# Altium schematic sheet Power.SchDoc(Power)
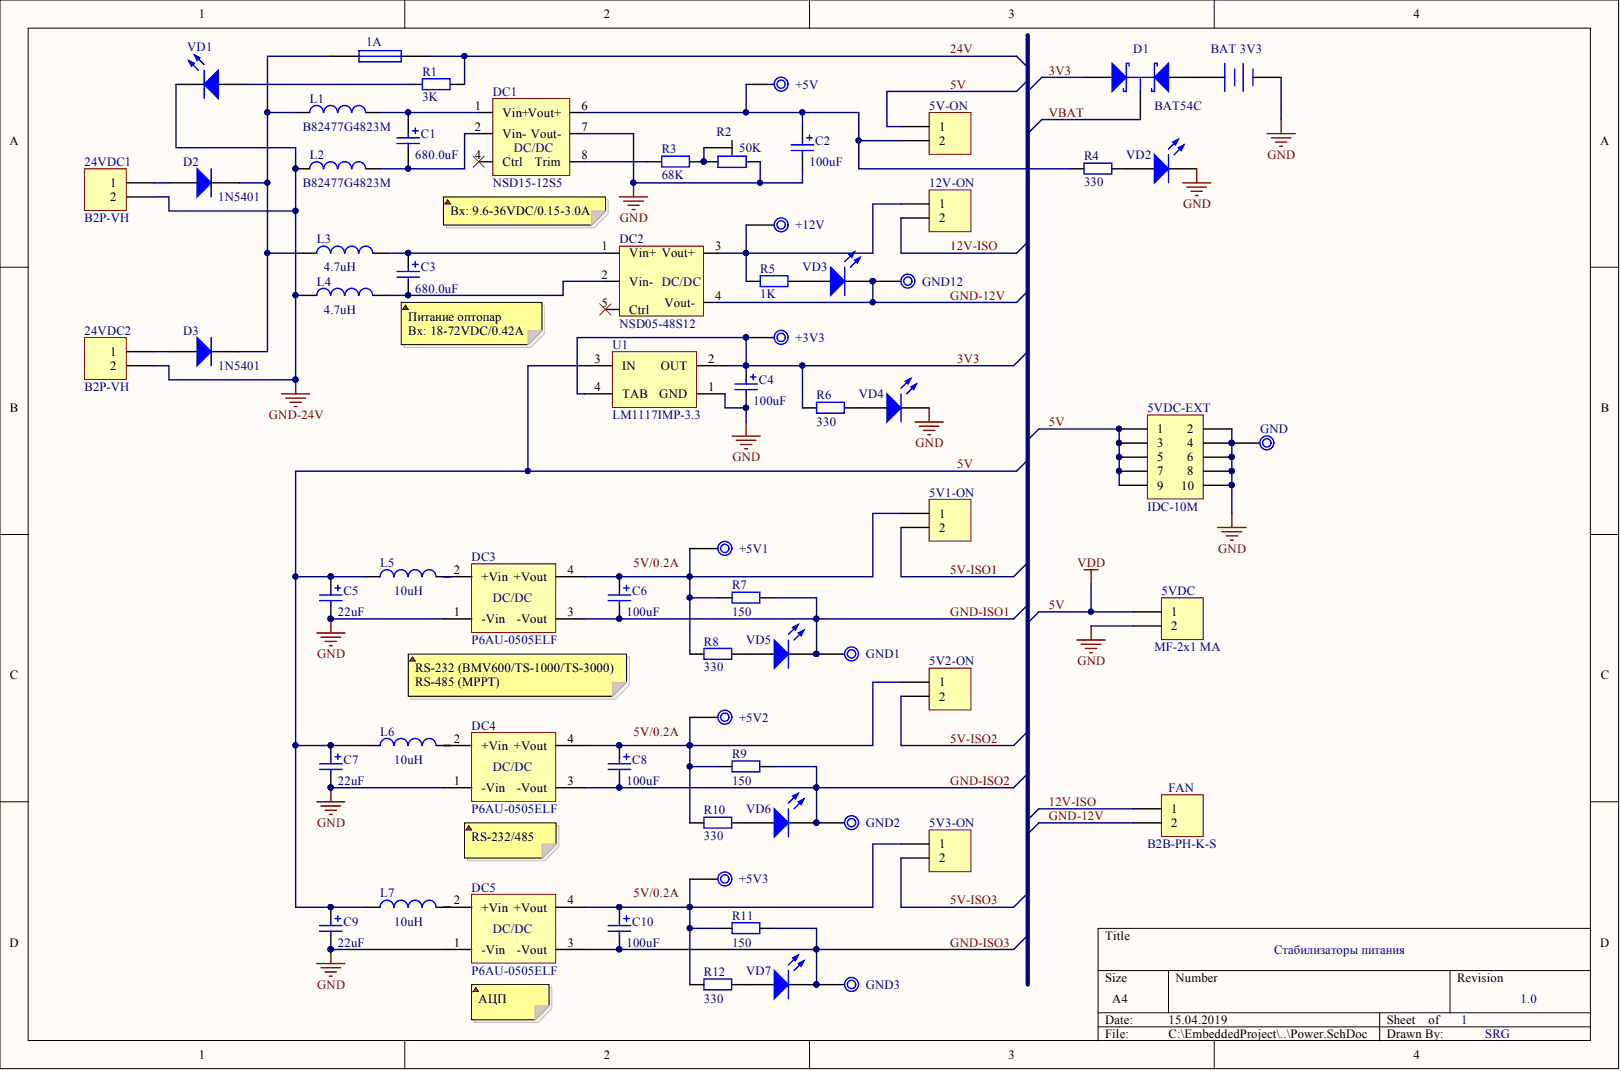
[diagram p0: Power.SchDoc]

## Parts
1A  value 1A  [FUSE RAD]  (hidden comment: Fuse 1)
5V1-ON  JP+12  [HDR1X2]  (value not drawn)
5V2-ON  JP+12  [HDR1X2]  (value not drawn)
5V3-ON  JP+12  [HDR1X2]  (value not drawn)
5V-ON  JP2  [HDR1X2]  (value not drawn)
5VDC  value MF-2x1 MA  [MF-2x1 MR]  (hidden comment: Header, 2-Pin)
5VDC-EXT  value IDC-10M  [IDC10]  (hidden comment: Header, 10-Pin)
12V-ON  JP2  [HDR1X2]  (value not drawn)
24VDC1  value B2P-VH  [B2P-VH]  (hidden comment: Header, 2-Pin)
24VDC2  value B2P-VH  [B2P-VH]  (hidden comment: Header, 2-Pin)
+3V3  Plug  [PIN1]  (value not drawn)
+5V  Plug  [PIN1]  (value not drawn)
+5V1  Plug  [PIN1]  (value not drawn)
+5V2  Plug  [PIN1]  (value not drawn)
+5V3  Plug  [PIN1]  (value not drawn)
+12V  Plug  [PIN1]  (value not drawn)
BAT_3V3  BAT 3V3  [CH-74-2032]
C1  value 680.0uF  [El-d13-h21-F5.08]  (hidden comment: Polarized Capacitor)
C2  value 100uF  [D]  (hidden comment: Polarized Capacitor)
C3  value 680.0uF  [El-d13-h21-F5.08]  (hidden comment: Polarized Capacitor)
C4  value 100uF  [D]  (hidden comment: Polarized Capacitor)
C5  value 22uF  [D]  (hidden comment: Polarized Capacitor)
C6  value 100uF  [D]  (hidden comment: Polarized Capacitor)
C7  value 22uF  [D]  (hidden comment: Polarized Capacitor)
C8  value 100uF  [D]  (hidden comment: Polarized Capacitor)
C9  value 22uF  [D]  (hidden comment: Polarized Capacitor)
C10  value 100uF  [D]  (hidden comment: Polarized Capacitor)
D1  value BAT54C  [SOT23_BAT54]  (hidden comment: Schottky Barrier Double Diode)
D2  value 1N5401  [DO-41]  (hidden comment: Diode 1N5401)
D3  value 1N5401  [DO-41]  (hidden comment: Diode 1N5401)
DC1  NSD15-12S5  [NSD15-12S5]
DC2  NSD05-48S12  [NSD05-12S12]
DC3  P6AU-0505ELF  [SIP4]
DC4  P6AU-0505ELF  [SIP4]
DC5  P6AU-0505ELF  [SIP4]
FAN  value B2B-PH-K-S  [B2B-PH-K-S]  (hidden comment: Header, 2-Pin)
GND  Plug  [PIN1]  (value not drawn)
GND1  Plug  [PIN1]  (value not drawn)
GND2  Plug  [PIN1]  (value not drawn)
GND3  Plug  [PIN1]  (value not drawn)
GND12  Plug  [PIN1]  (value not drawn)
L1  value B82477G4823M  [B82477G4823M]  (hidden comment: Inductor)
L2  value B82477G4823M  [B82477G4823M]  (hidden comment: Inductor)
L3  value 4.7uH  [Axial 12]  (hidden comment: Inductor)
L4  value 4.7uH  [Axial 12]  (hidden comment: Inductor)
L5  value 10uH  [Axial 12]  (hidden comment: Inductor)
L6  value 10uH  [Axial 12]  (hidden comment: Inductor)
L7  value 10uH  [Axial 12]  (hidden comment: Inductor)
R1  value 3K  [1206]  (hidden comment: Res2)
R2  value 50K  [RES-3296W]  (hidden comment: Resistor TAP)
R3  value 68K  [1206]  (hidden comment: Res2)
R4  value 330  [1206]  (hidden comment: Res2)
R5  value 1K  [1206]  (hidden comment: Res2)
R6  value 330  [1206]  (hidden comment: Res2)
R7  value 150  [MLT-0.5W-500]  (hidden comment: Res2)
R8  value 330  [1206]  (hidden comment: Res2)
R9  value 150  [RES 12.7x3.0]  (hidden comment: Res2)
R10  value 330  [1206]  (hidden comment: Res2)
R11  value 150  [RES 12.7x3.0]  (hidden comment: Res2)
R12  value 330  [1206]  (hidden comment: Res2)
U1  LM1117IMP-3.3  [MP04A_N]
VD1  +24V-OK  [LED1206 - GREEN]  (value not drawn)
VD2  5V  [LED1206 - GREEN]  (value not drawn)
VD3  12V  [LED1206 - BLUE]  (value not drawn)
VD4  3V3  [LED1206 - GREEN]
VD5  5V1  [LED1206 - BLUE]  (value not drawn)
VD6  5V2  [LED1206 - BLUE]  (value not drawn)
VD7  5V3  [LED1206 - BLUE]  (value not drawn)

## Nets
GND: 5VDC.2 5VDC-EXT.2 5VDC-EXT.4 5VDC-EXT.6 5VDC-EXT.8 5VDC-EXT.10 BAT_3V3.2 C2.2 C4.2 C5.2 C7.2 C9.2 DC1.7(Vout-) DC3.1(-Vin) DC4.1(-Vin) DC5.1(-Vin) GND.1 R2.1 U1.1(GND) VD2.2 VD4.2
GND-24V: 24VDC1.2 24VDC2.2 L2.1 L4.1 VD1.2
3V3: +3V3.1 C4.1 D1.1 R6.2 U1.2(OUT) U1.4(TAB)
5V: 5V-ON.1 5VDC.1 5VDC-EXT.1 5VDC-EXT.3 5VDC-EXT.5 5VDC-EXT.7 5VDC-EXT.9 C5.1 C7.1 C9.1 L5.1 L6.1 L7.1 U1.3(IN)
5V-ISO1: 5V1-ON.2
5V-ISO2: 5V2-ON.2
5V-ISO3: 5V3-ON.2
12V-ISO: 12V-ON.2 FAN.1
24V: 1A.2 R1.2
GND-12V: DC2.4(Vout-) FAN.2 GND12.1 VD3.2
GND-ISO1: C6.2 DC3.3(-Vout) GND1.1 R7.1 VD5.2
GND-ISO2: C8.2 DC4.3(-Vout) GND2.1 R9.1 VD6.2
GND-ISO3: C10.2 DC5.3(-Vout) GND3.1 R11.1 VD7.2
VBAT: D1.3
Net1A_1: 1A.1 D2.2 D3.2 L1.1 L3.1
Net24VDC1_1: 24VDC1.1 D2.1
Net24VDC2_1: 24VDC2.1 D3.1
Net+5V1_1: 5V1-ON.1 +5V1.1 C6.1 DC3.4(+Vout) R7.2 R8.2
Net+5V2_1: 5V2-ON.1 +5V2.1 C8.1 DC4.4(+Vout) R9.2 R10.2
Net+5V3_1: 5V3-ON.1 +5V3.1 C10.1 DC5.4(+Vout) R11.2 R12.2
Net+5V_1: 5V-ON.2 +5V.1 C2.1 DC1.6(Vout+) R4.2
Net+12V_1: 12V-ON.1 +12V.1 DC2.3(Vout+) R5.2
NetBAT 3V3_1: BAT_3V3.1 D1.2
NetC1_1: C1.1 DC1.1(Vin+) L1.2
NetC1_2: C1.2 DC1.2(Vin-) L2.2
NetC3_1: C3.1 DC2.1(Vin+) L3.2
NetC3_2: C3.2 DC2.2(Vin-) L4.2
NetDC1_8: DC1.8(Trim) R3.2
NetDC3_2: DC3.2(+Vin) L5.2
NetDC4_2: DC4.2(+Vin) L6.2
NetDC5_2: DC5.2(+Vin) L7.2
NetR1_1: R1.1 VD1.1
NetR2_2: R2.2 R2.3 R3.1
NetR4_1: R4.1 VD2.1
NetR5_1: R5.1 VD3.1
NetR6_1: R6.1 VD4.1
NetR8_1: R8.1 VD5.1
NetR10_1: R10.1 VD6.1
NetR12_1: R12.1 VD7.1
N00552:

Not connected: DC1 4(Ctrl); DC2 5(Ctrl)

## Library data of the parts
1A = Fuse 1: Fuse; Component Kind: Standard; LatestRevisionDate: 17-Jul-2002; LatestRevisionNote: Re-released for DXP Platform.; Library Name: Miscellaneous Devices.IntLib; PackageDescription: Thru-Hole; 2 Leads; PackageReference: PIN-W2/E2.8; Published: 8-Jun-2000; Simulation: FUSE; Publisher: Altium Limited
5V1-ON 5V2-ON 5V3-ON 5V-ON 12V-ON = Header 2: Header, 2-Pin; value: Jumper; Component Kind: Standard; LatestRevisionDate: 17-Jul-2002; LatestRevisionNote: Re-released for DXP Platform.; Library Name: Miscellaneous Connectors.IntLib; Signal Integrity: Connector; Publisher: Altium Limited
5VDC 24VDC1 24VDC2 FAN = Header 2: Component Kind: Standard; Description: Header, 2-Pin; LatestRevisionDate: 17-Jul-2002; LatestRevisionNote: Re-released for DXP Platform.; Library Name: Miscellaneous Connectors.IntLib; Signal Integrity: Connector; Publisher: Altium Limited
5VDC-EXT = Header 5X2: Component Kind: Standard; Description: Header, 10-Pin; LatestRevisionDate: 17-Jul-2002; LatestRevisionNote: Re-released for DXP Platform.; Library Name: Miscellaneous Connectors.IntLib; Signal Integrity: Connector; Publisher: Altium Limited
BAT_3V3 = Battery: Multicell Battery; Value: CH-74-2032; Component Kind: Standard; LatestRevisionDate: 17-Jul-2002; LatestRevisionNote: Re-released for DXP Platform.; Library Name: Miscellaneous Devices.IntLib; PackageReference: BAT-2; Published: 24-Mar-1999; Publisher: Altium Limited
C1-C10 = Cap Pol2: Component Kind: Standard; Description: Polarized Capacitor; LatestRevisionDate: 17-Jul-2002; LatestRevisionNote: Re-released for DXP Platform.; Library Name: Miscellaneous Devices.IntLib; PackageReference: POLAR0.8; Published: 8-Jun-2000; Signal Integrity: Cap Pol; Simulation: CAP; Publisher: Altium Limited
D1 = BAT54C: Component Kind: Standard; ComponentLink1Description: Manufacturer Link; ComponentLink1URL: http://www.semiconductors.philips.com/; ComponentLink2Description: Datasheet; ComponentLink2URL: http://www.semiconductors.philips.com/acrobat/datasheets/BAT54_SERIES_4.pdf; DatasheetVersion: 4-Mar-2002; Description: Schottky Barrier Double Diode; LatestRevisionDate: 12-Dec-2006; LatestRevisionNote: IPC-7351 Footprint Added.; Library Name: Philips Discrete Diode - Schottky.IntLib; PackageDescription: 3-Pin SOT-23 Package 0.95 mm Pitch, 2.3 mm Lead Span; PackageReference: SOT23; PackageVersion: May-1999; Published: 17-Sep-2003; Signal Integrity: BAT54C; Simulation: BAT54C; Publisher: Altium Limited
D2 D3 = Diode 1N5401: 3 Amp General Purpose Rectifier; Component Kind: Standard; ComponentLink1Description: Manufacturer Link; ComponentLink1URL: http://www.fairchildsemi.com/; ComponentLink2Description: Datasheet; ComponentLink2URL: http://www.fairchildsemi.com/ds/1N%2F1N5400.pdf; DatasheetVersion: 1998; LatestRevisionDate: 17-Jul-2002; LatestRevisionNote: Re-released for DXP Platform.; Library Name: Miscellaneous Devices.IntLib; PackageDescription: Diode, Axial; Body 9.5 x 5.6 mm (LxDia. max), Lead Dia. 1.32 mm (max); PackageReference: DO-201AD; PackageVersion: Aug-1999; Published: 8-Jun-2000; Signal Integrity: 1N5401; Simulation: 1N5401; Publisher: Altium Limited
DC1 = NSD15-12S5: DC/DC 9-36V/5V; Component Kind: Standard; Library Name: Schlib1.SchLib; Value: NSD15-12S5
DC2 = NSD05-48S12: DC/DC 18-72VDC/12V; Component Kind: Standard; Library Name: Schlib1.SchLib; value: NSD05-48S12
DC3-DC5 = P6AU-0505ELF: DC/DC 5V/5V; Component Kind: Standard; Library Name: Schlib1.SchLib; Value: P6AU-0505ELF
L1 L2 L4-L7 = Inductor: Code_IEC: 1005; Code_JEITA: 0402; Component Kind: Standard; Description: Inductor; LatestRevisionDate: 29-May-2009; LatestRevisionNote: IPC-7351 Footprint Added.; Library Name: Miscellaneous Devices.IntLib; PackageDescription: Chip Inductor; PackageReference: 0402-A; Published: 8-Jun-2000; Signal Integrity: Inductor; Simulation: INDUCTOR; Publisher: Altium Limited
L3 = Inductor: Code_IEC: 1005; Value: 4.7uH; Code_JEITA: 0402; Component Kind: Standard; Description: Inductor; LatestRevisionDate: 29-May-2009; LatestRevisionNote: IPC-7351 Footprint Added.; Library Name: Miscellaneous Devices.IntLib; PackageDescription: Chip Inductor; PackageReference: 0402-A; Published: 8-Jun-2000; Signal Integrity: Inductor; Simulation: INDUCTOR; Publisher: Altium Limited
R1 R3-R12 = Res2: Resistor; Component Kind: Standard; LatestRevisionDate: 17-Jul-2002; LatestRevisionNote: Re-released for DXP Platform.; Library Name: Miscellaneous Devices.IntLib; PackageReference: AXIAL-0.4; Published: 8-Jun-2000; Signal Integrity: Res; Simulation: RESISTOR; Publisher: Altium Limited
  R7 R9 R11: PackageDescription: Axial Device, Thru-Hole; 2 Leads; 0.4 in Pin Spacing
R2 = Res TAP: Code_IPC: RESC2012; Component Kind: Standard; Description: Resistor TAP; LatestRevisionDate: 29-May-2009; LatestRevisionNote: IPC-7351 Footprint Added.; Library Name: Schlib1.SchLib; PackageDescription: Chip Resistor 0805 Case Size; PackageReference: 6-0805; PackageVersion: Mar-2003; Published: 24-Mar-1999; Publisher: Altium Limited
U1 = LM1117IMP-3.3: 800mA Low-Dropout Linear Regulator, 4-pin SOT-223; Manufacturer: National Semiconductor; CompType: LDO IC; High-Reliability: Y; PackageTopMarking: XYTT*N05B; PowerWise: N; Code_IPC: SOT223-4; Code_JEDEC: TO-261-AA; Component Kind: Standard; ComponentLink1Description: Manufacturer URL; ComponentLink1URL: http://www.national.com/; ComponentLink2Description: LM1117 Datasheet; ComponentLink2URL: http://www.national.com/ds/LM/LM1117.pdf; ComponentLink3Description: LM1117 Web Page; ComponentLink3URL: http://www.national.com/pf/LM/LM1117.html; ComponentLink4Description: MP04A Package Page; ComponentLink4URL: http://www.national.com/packaging/folders/mp04a.html; DatasheetVersion: Apr-2006; Library Name: National Semiconductor LDO.IntLib; PackageDescription: Molded SOT-223, 6.50 x 3.56 x 1.6mm Body, 4 Lead, 2.3mm Pitch; PackageReference: MP04A; PackageVersion: revB, Apr-2003; PartNumber: LM1117IMP-3.3; PinCount: 4; RoHS: N; Value: LM1117IMP-3.3
VD1 = LED0: SMD LED; value: WHITE; Component Kind: Standard; LatestRevisionDate: 08-Jul-2005; LatestRevisionNote: Mech Layer 1 removed.; Library Name: Miscellaneous Devices.IntLib; PackageReference: LED-0; Published: 8-Jun-2000; Simulation: LED0; Publisher: Altium Limited
VD2 VD4 = LED0: SMD LED; Value: GREEN; Component Kind: Standard; LatestRevisionDate: 08-Jul-2005; LatestRevisionNote: Mech Layer 1 removed.; PackageDescription: LED; 2 Leads; PackageReference: LED-0; Published: 8-Jun-2000; Simulation: LED0; Publisher: Altium Limited
  VD2: Library Name: Miscellaneous Devices.IntLib
  VD4: Library Name: Schlib1.SchLib
VD3 VD5-VD7 = LED0: SMD LED; Value: BLUE; Component Kind: Standard; LatestRevisionDate: 08-Jul-2005; LatestRevisionNote: Mech Layer 1 removed.; Library Name: Schlib1.SchLib; PackageDescription: LED; 2 Leads; PackageReference: LED-0; Published: 8-Jun-2000; Simulation: LED0; Publisher: Altium Limited
+3V3 +5V +5V1-+5V3 +12V GND GND1-GND3 GND12 = Plug: Component Kind: Standard; Description: Plug; LatestRevisionDate: 17-Jul-2002; LatestRevisionNote: Re-released for DXP Platform.; Library Name: Schlib1.SchLib; Signal Integrity: Connector; Value: Plug; Publisher: Altium Limited
